# Altium schematic sheet PS3604LD.SchDoc(PS3604LD)
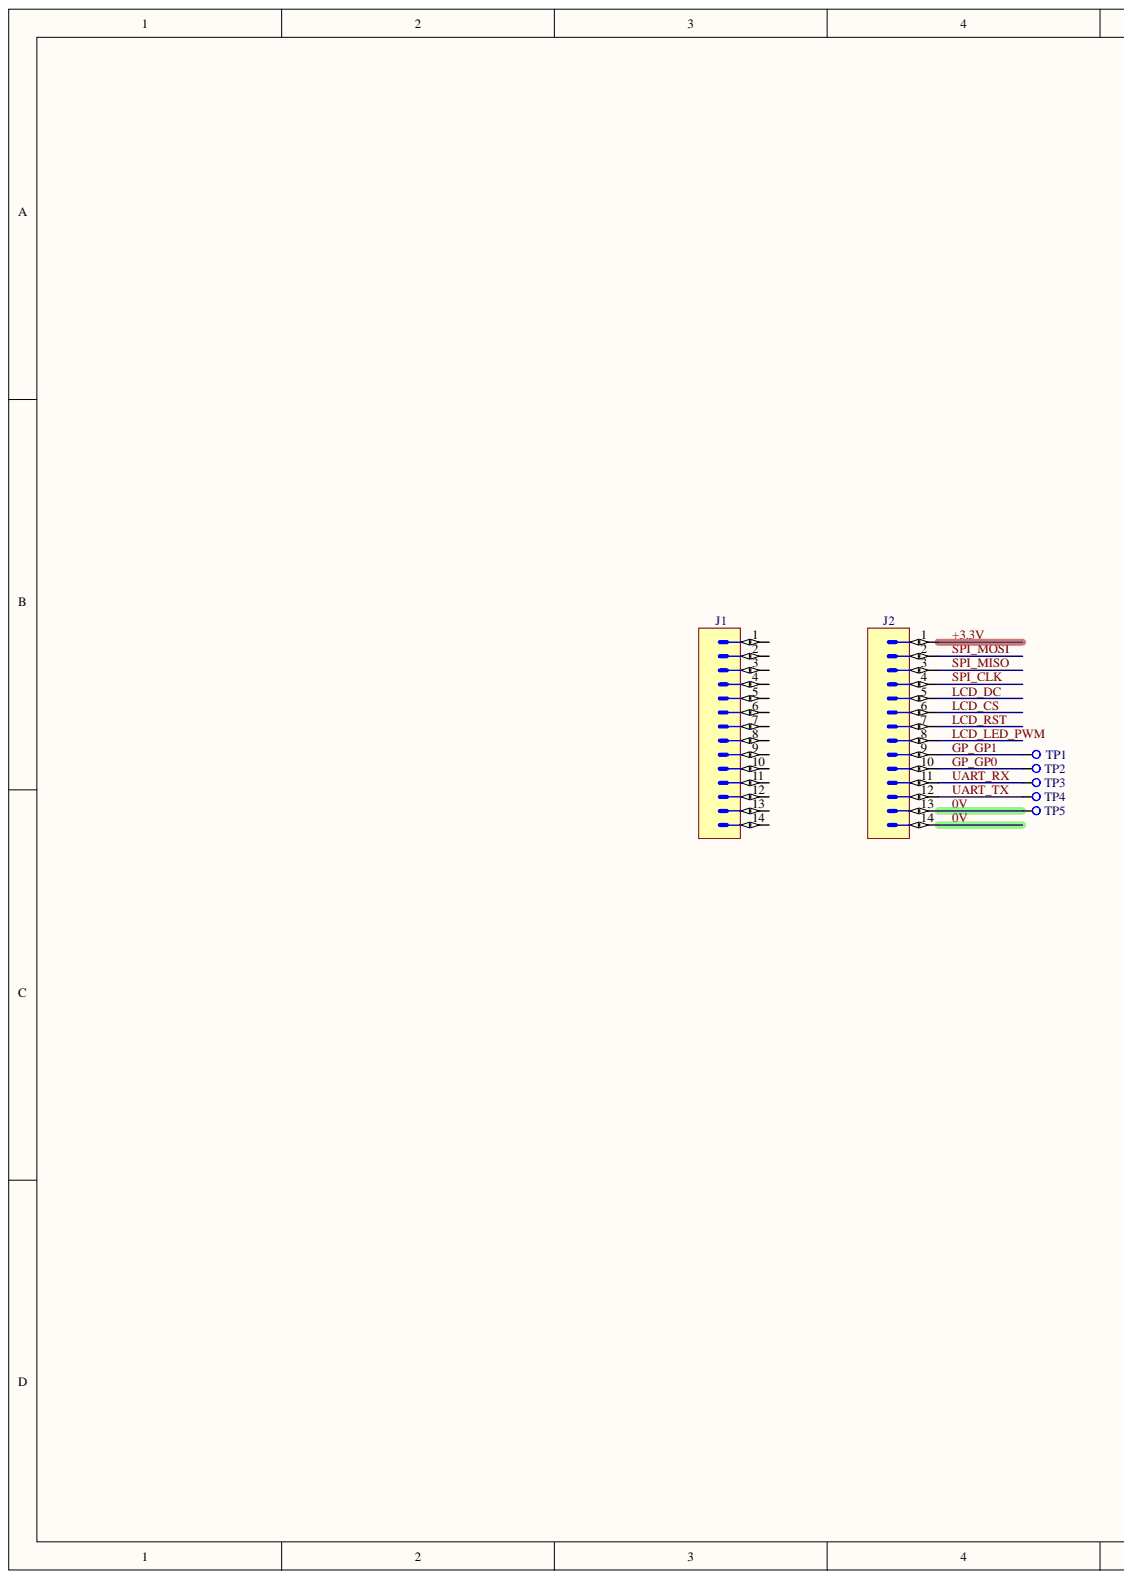
[diagram p0: PS3604LD.SchDoc, left]
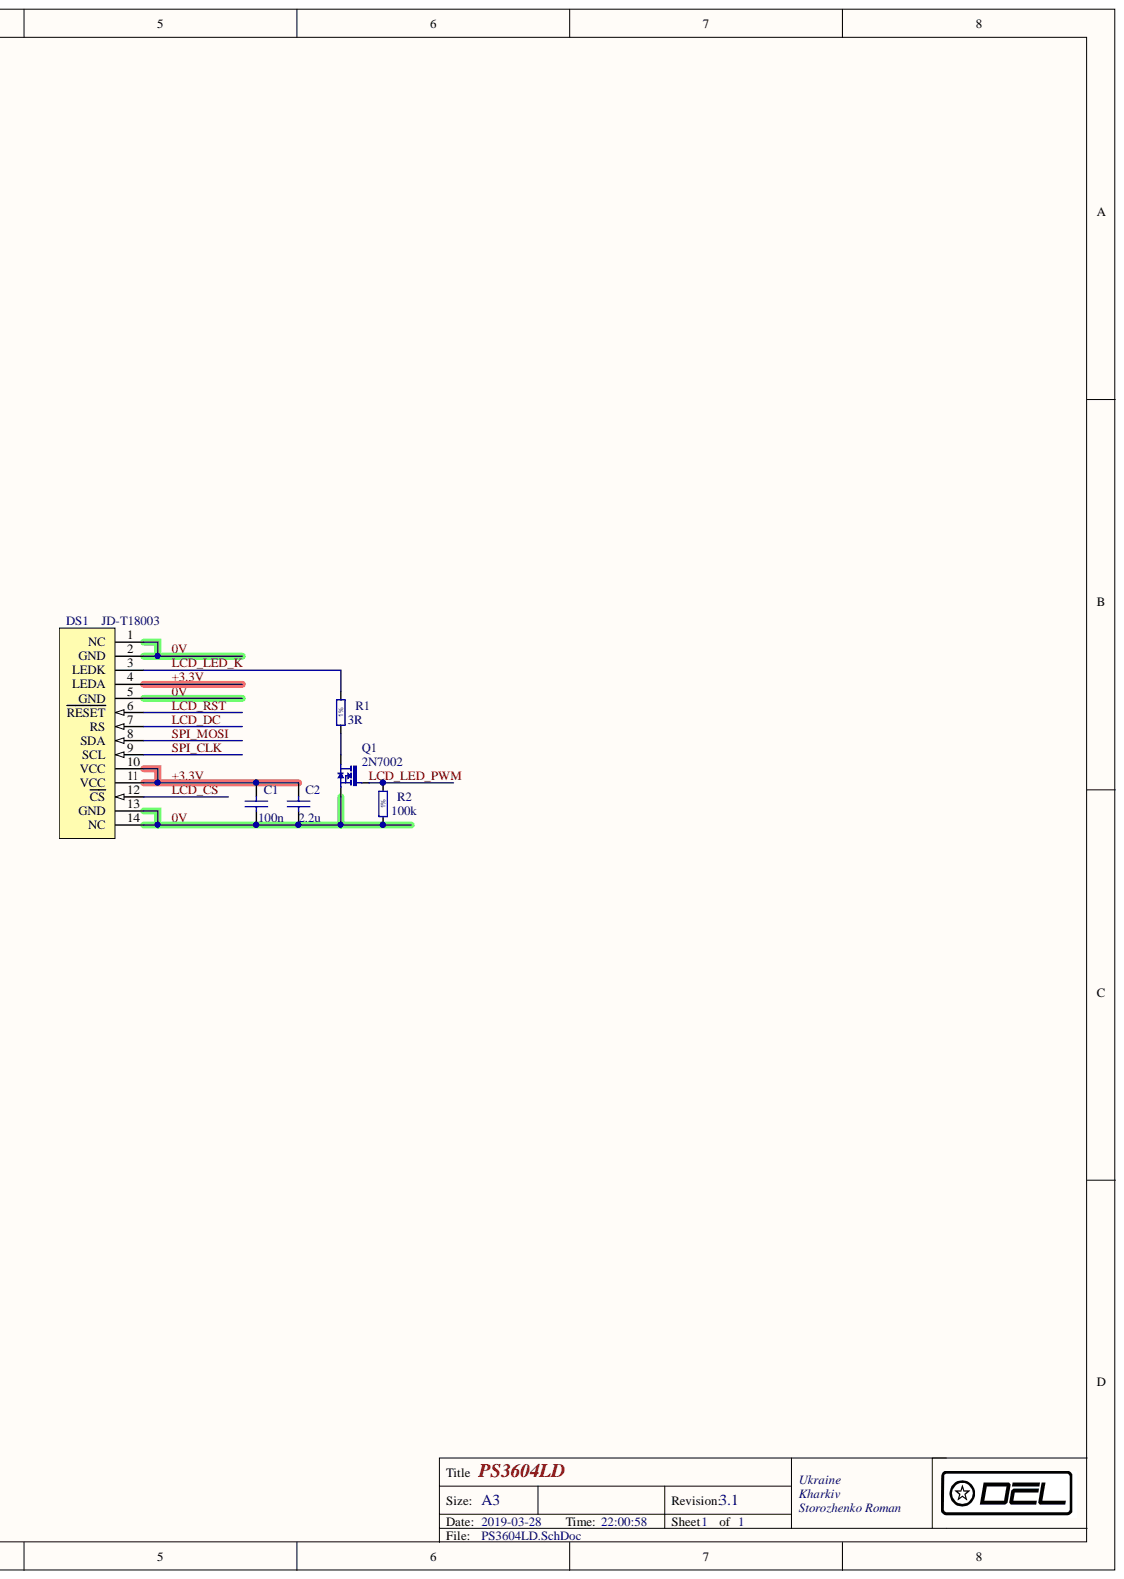
[diagram p1: PS3604LD.SchDoc, right]

## Parts
C1  100n  [CAPC1005X55N]
C2  2.2u  [CAPC1005X55N]
DS1  JD-T18003  [JD_T18003]
J1  Socket 14  [3M_951114-8622-AR]  (value not drawn)
J2  Socket 14  [3M_951114-8622-AR]  (value not drawn)
Q1  2N7002  [SOT95P240X130-3N]
R1  3R  [RESC2012X60N]
R2  100k  [RESC1005X40N]
TP1  Test Point  [TP_SMD_800]  (value not drawn)  (no BOM)
TP2  Test Point  [TP_SMD_800]  (value not drawn)  (no BOM)
TP3  Test Point  [TP_SMD_800]  (value not drawn)  (no BOM)
TP4  Test Point  [TP_SMD_800]  (value not drawn)  (no BOM)
TP5  Test Point  [TP_SMD_800]  (value not drawn)  (no BOM)

## Nets
0V: C1.1 C2.1 DS1.1(NC) DS1.2(GND) DS1.5(GND) DS1.13(GND) DS1.14(NC) J2.13 J2.14 Q1.2 R2.1 TP5.1
+3.3V: C1.2 C2.2 DS1.4(LEDA) DS1.10(VCC) DS1.11(VCC) J2.1
GP_GP0: J2.10 TP2.1
GP_GP1: J2.9 TP1.1
LCD_CS: DS1.12(CS) J2.6
LCD_DC: DS1.7(RS) J2.5
LCD_LED_K: DS1.3(LEDK) R1.2
LCD_LED_PWM: J2.8 Q1.1 R2.2
LCD_RST: DS1.6(RESET) J2.7
SPI_CLK: DS1.9(SCL) J2.4
SPI_MISO: J2.3
SPI_MOSI: DS1.8(SDA) J2.2
UART_RX: J2.11 TP3.1
UART_TX: J2.12 TP4.1
NetQ1_3: Q1.3 R1.1

Not connected: J1 1 2 3 4 5 6 7 8 9 10 11 12 13 14

## Library data of the parts
C1 = CC0402_100NF_16V_10%_X7R: Non-polarised capacitor; Part Description: SMD Multilayer Chip Ceramic Capacitor; Manufacturer1 Part Number: 223878715649; Manufacturer: GENERIC; Voltage: 16V; Tolerance: ±10%; TC: X7R; Database Table Name: Capacitors SMD; Value: 100n; Status: Not Recommended; Socket: No; SMD: Yes; Pin Count: 2; Part Number: CC0402_100NF_16V_10%_X7R; Mounted: Yes; Manufacturer Part Number: CC0402_100NF_16V_10%_X7R; Manufacturer1 Example: PHYCOMP; Manufacturer1 ComponentHeight: 0.55mm; Library Name: Capacitors.DbLib; LatestRevisionDate: 03.12.2007; HelpURL: \\cern.ch\dfs\Applications\Altium\Datasheets\CC0402_X7R_PHYCOMP.pdf; CreateDate: 03.12.2007; Component Type: Standard; Component Kind: Standard; Case: 0402; Author: CERN DEM JLC
C2 = CC0402_2.2UF_6.3V_20%_X5R: Non-polarised capacitor; Part Description: SMD Multilayer Chip Ceramic Capacitor; Manufacturer: GENERIC; Voltage: 6.3V; Tolerance: ±20%; TC: X5R; Database Table Name: Capacitors SMD; Value: 2.2u; Status: Preferred; Socket: No; SMD: Yes; Pin Count: 2; Part Number: CC0402_2.2UF_6.3V_20%_X5R; Mounted: Yes; Manufacturer Part Number: CC0402_2.2UF_6.3V_20%_X5R; Manufacturer1 ComponentHeight: 0.55mm; Library Name: Capacitors.DbLib; LatestRevisionDate: 19.05.2015; HelpURL: \\cern.ch\dfs\Applications\Altium\Datasheets\CC0402_X5R_KEMET.pdf; CreateDate: 19.05.2015; Component Type: Standard; Component Kind: Standard; Case: 0402; Author: ELMAN
DS1 = JD_T18003: Part Description: 1.8" SPI TFT display, 160x128 18-bit color - ST7735R driver; Manufacturer Part Number: JD-T18003-T01; Manufacturer: Truly Semiconductors Inc.; Database Table Name: LEDs & Displays; Color: 16 bit / pix; Socket: No; SMD: Yes; Pin Count: 14; Part Number: JD_T18003; PackageDescription: 34.7 x 46.7 x 2.6 mm; Mounted: Yes; Library Name: ICs And Semiconductors.DbLib; LatestRevisionDate: 26.02.2018; Device: JD-T18003; CreateDate: 26.02.2018; Component Type: Standard; Component Kind: Standard; ComponentHeight: 2.6mm; Author: DEL
J1 J2 = 3M_951114-8622-AR: Connector 14 Male; Part Description: 2mm Pin Strip Header, 951 Series; Manufacturer: 3M; Status: None; Socket: No; SMD: No; Pin Count: 14; Part Number: 3M_951114-8622-AR; Mounted: Yes; Manufacturer Part Number: 951114-8622-AR; Library Name: Connectors.DbLib; LatestRevisionDate: 04.11.2017; Family: Socket 14; Database Table Name: 3M; CreateDate: 04.11.2017; Component Type: Standard; Component Kind: Standard; ComponentHeight: 5.9mm; Author: DEL
Q1 = 2N7002: N-CHANNEL MOSFET WITH DIODE; Part Description: 60V 280mA N-Channel Enhancement Mode Field Effect Transistor (FET); Manufacturer: FAIRCHILD SEMICONDUCTOR; Database Table Name: Transistors; Socket: No; SMD: Yes; Pin Count: 3; Part Number: 2N7002; PackageDescription: SOT23 3-Leads, Pitch 0.95mm - Body 1.3x2.9mm, IPC Medium Density; Mounted: Yes; Manufacturer Part Number: 2N7002; Library Name: ICs And Semiconductors.DbLib; LatestRevisionDate: 07.04.2009; HelpURL: \\cern.ch\dfs\Applications\Altium\Datasheets\2N7002.pdf; Device: 2N7002; CreateDate: 20.08.2008; Component Type: Standard; Component Kind: Standard; ComponentHeight: 1.3mm; Case: SOT23-3; Author: CERN DEM JLC
R1 = R0805_3R_1%_0.125W_200PPM: Resistor - 1%; Part Description: General Purpose Thick Film Chip Resistor; Manufacturer1 Part Number: 232273463008; Manufacturer: GENERIC; TC: ±200ppm/°C; Power: 0.125W; Database Table Name: Resistors SMD; Value: 3R; Tolerance: ±1%; Status: Preferred; Socket: No; SMD: Yes; Pin Count: 2; Part Number: R0805_3R_1%_0.125W_200PPM; Mounted: Yes; Manufacturer Part Number: R0805_3R_1%_0.125W_200PPM; Manufacturer1 Example: PHYCOMP; Manufacturer1 ComponentHeight: 0.65mm; Library Name: Resistors.DbLib; LatestRevisionDate: 24.06.2009; HelpURL: \\cern.ch\dfs\Applications\Altium\Datasheets\R0805_Phycomp_RC0805.pdf; CreateDate: 03.12.2007; Component Type: Standard; Component Kind: Standard; Case: 0805; Author: CERN DEM JLC
R2 = R0402_100K_1%_0.0625W_100PPM: Resistor - 1%; Part Description: General Purpose Thick Film Chip Resistor; Manufacturer1 Part Number: 232270671004; Manufacturer: GENERIC; TC: ±100ppm/°C; Database Table Name: Resistors SMD; Value: 100k; Tolerance: ±1%; Status: Not recommended; Socket: No; SMD: Yes; Power: 0.0625W; Pin Count: 2; Part Number: R0402_100K_1%_0.0625W_100PPM; Mounted: Yes; Manufacturer Part Number: R0402_100K_1%_0.0625W_100PPM; Manufacturer1 Example: PHYCOMP; Manufacturer1 ComponentHeight: 0.4mm; Library Name: Resistors.DbLib; LatestRevisionDate: 13.03.2008; HelpURL: \\cern.ch\dfs\Applications\Altium\Datasheets\R0402_Phycomp_RC0402.pdf; CreateDate: 03.12.2007; Component Type: Standard; Component Kind: Standard; Case: 0402; Author: CERN DEM JLC
TP1-TP5 = TP_SMD_0.8MM: Part Description: 0.8mm round SMD Pad; Mounted: No; Database Table Name: Pads; Status: None; Socket: No; SMD: No; Pin Count: 1; Part Number: TP_SMD_0.8MM; PackageDescription: 0.8mm round SMD Pad; Library Name: Eletro-mechanical.DbLib; LatestRevisionDate: 14.11.2017; Family: Test Point; Description: Test Point; CreateDate: 14.11.2017; Component Type: Standard (No BOM); ComponentHeight: 0mm; Author: DEL
